# Altium schematic sheet Channels [1,2].SchDoc("Channels [1,2]")
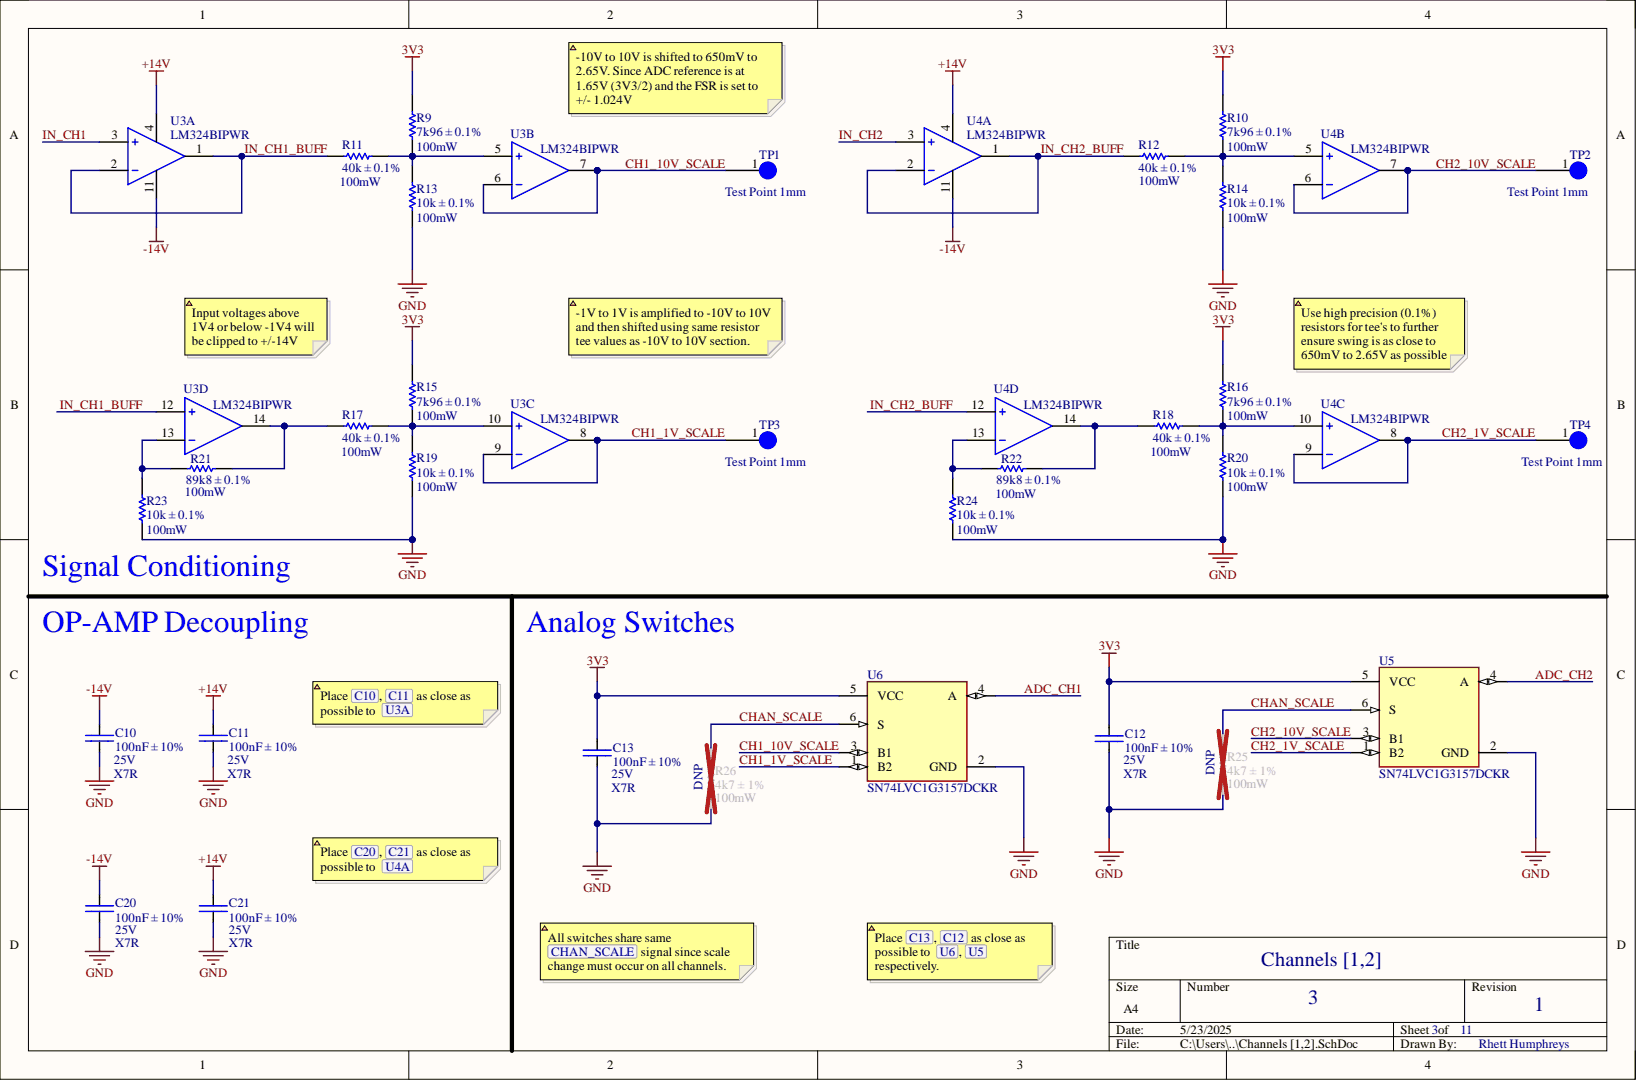
[diagram p0: Channels [1,2].SchDoc]

## Parts
C10  100nF ± 10%  [CAP-0603]
C11  100nF ± 10%  [CAP-0603]
C12  100nF ± 10%  [CAP-0603]
C13  100nF ± 10%  [CAP-0603]
C20  100nF ± 10%  [CAP-0603]
C21  100nF ± 10%  [CAP-0603]
R9  7k96 ± 0.1%  [RES-0603]
R10  7k96 ± 0.1%  [RES-0603]
R11  40k ± 0.1%  [RES-0603]
R12  40k ± 0.1%  [RES-0603]
R13  10k ± 0.1%  [RES-0603]
R14  10k ± 0.1%  [RES-0603]
R15  7k96 ± 0.1%  [RES-0603]
R16  7k96 ± 0.1%  [RES-0603]
R17  40k ± 0.1%  [RES-0603]
R18  40k ± 0.1%  [RES-0603]
R19  10k ± 0.1%  [RES-0603]
R20  10k ± 0.1%  [RES-0603]
R21  89k8 ± 0.1%  [RES-0603]
R22  89k8 ± 0.1%  [RES-0603]
R23  10k ± 0.1%  [RES-0603]
R24  10k ± 0.1%  [RES-0603]
R25  4k7 ± 1%  [RES-0603]
R26  4k7 ± 1%  [RES-0603]
TP1  Test Point 1mm  [Test Point 1mm]
TP2  Test Point 1mm  [Test Point 1mm]
TP3  Test Point 1mm  [Test Point 1mm]
TP4  Test Point 1mm  [Test Point 1mm]
U3  LM324BIPWR  [FP-PW0014A-MFG]  (parts A,B,C,D)
U4  LM324BIPWR  [FP-PW0014A-MFG]  (parts A,B,C,D)
U5  SN74LVC1G3157DCKR  [FP-DCK0006A-IPC_B]
U6  SN74LVC1G3157DCKR  [FP-DCK0006A-IPC_B]

## Nets
3V3: C12.2 C13.2 R9.1 R10.1 R15.1 R16.1 U5.5(VCC) U6.5(VCC)
+14V: C11.2 C21.2 U3.4 U4.4
-14V: C10.2 C20.2 U3.11 U4.11
GND: C10.1 C11.1 C12.1 C13.1 C20.1 C21.1 R13.2 R14.2 R19.2 R20.2 R23.2 R24.2 R25.2 R26.2 U5.2(GND) U6.2(GND)
ADC_CH1: U6.4(A)
ADC_CH2: U5.4(A)
CH1_1V_SCALE: TP3.1 U3.8 U3.9 U6.1(B2)
CH1_10V_SCALE: TP1.1 U3.6 U3.7 U6.3(B1)
CH2_1V_SCALE: TP4.1 U4.8 U4.9 U5.1(B2)
CH2_10V_SCALE: TP2.1 U4.6 U4.7 U5.3(B1)
CHAN_SCALE: R25.1 R26.1 U5.6(S) U6.6(S)
IN_CH1: U3.3
IN_CH1_BUFF: R11.2 U3.1 U3.2 U3.12
IN_CH2: U4.3
IN_CH2_BUFF: R12.2 U4.1 U4.2 U4.12
NetR9_2: R9.2 R11.1 R13.1 U3.5
NetR10_2: R10.2 R12.1 R14.1 U4.5
NetR15_2: R15.2 R17.1 R19.1 U3.10
NetR16_2: R16.2 R18.1 R20.1 U4.10
NetR17_2: R17.2 R21.1 U3.14
NetR18_2: R18.2 R22.1 U4.14
NetR21_2: R21.2 R23.1 U3.13
NetR22_2: R22.2 R24.1 U4.13

## Library data of the parts
C10-C13 C20 C21 = CAP-0603: Capacitor chip unpolarized. 0603; Component Kind: Standard; Library Name: Master.SchLib
R9-R26 = RES-0603: Resistor chip. 0603; Component Kind: Standard; Library Name: Master.SchLib
U3 U4 = CMP-00370-131314463-1: LM324BIPWR Quad, 36-V, 1.2-MHz 3-mV offset voltage operational amplifier 14-TSSOP -40 to 85; Manufacturer Lifecycle Status: ACTIVE; Case/Package: TSSOP; Component Kind: Standard; Height: 1.2mm; Length: 5mm; Library Name: Altium Content Vault; Lifecycle Status: Production; NexarPartId: 131314463; Number of Pins: 14; Thickness: 1mm; Width: 4.4mm
U5 U6 = CMP-0859-00516-4: IC SWITCH SPDT SC70-6; Bandwidth: 300MHz; High Level Output Current: 128mA; Max Supply Current: 10uA; Max Supply Voltage: 5.5V; Min Supply Voltage: 1.65V; Number of Bits: 1; Number of Outputs: 2; On-State Resistance: 15R; Operating Supply Current: 1uA; Polarity: Non-Inverting; Propagation Delay: 300ps; Supply Type: Single; Turn-Off Delay Time: 3.8ns; Turn-On Delay Time: 5.7ns; Case/Package: SC; Component Kind: Standard; Contact Plating: Gold; Height: 0.9mm; Lead Free: Lead Free; Length: 2mm; Library Name: Altium Content Vault; Low Level Output Current: 128mA; Max Operating Temperature: 125°C; Min Operating Temperature: -40°C; Mount: Surface Mount; Nominal Supply Current: 10uA; Number of Channels: 1; Number of Inputs: 1; Number of Pins: 6; Package Quantity: 3000; Packaging: Tape and Reel; Radiation Hardening: No; REACH SVHC: No SVHC; RoHS Compliant: Yes; Termination: SMD/SMT; Throw Configuration: SPDT; Weight: 8.8E-05oz; Width: 1.25mm
TP1-TP4 = Test Point 1mm: Component Kind: Standard; Library Name: Master.SchLib
